# Altium schematic sheet main.SchDoc(main)
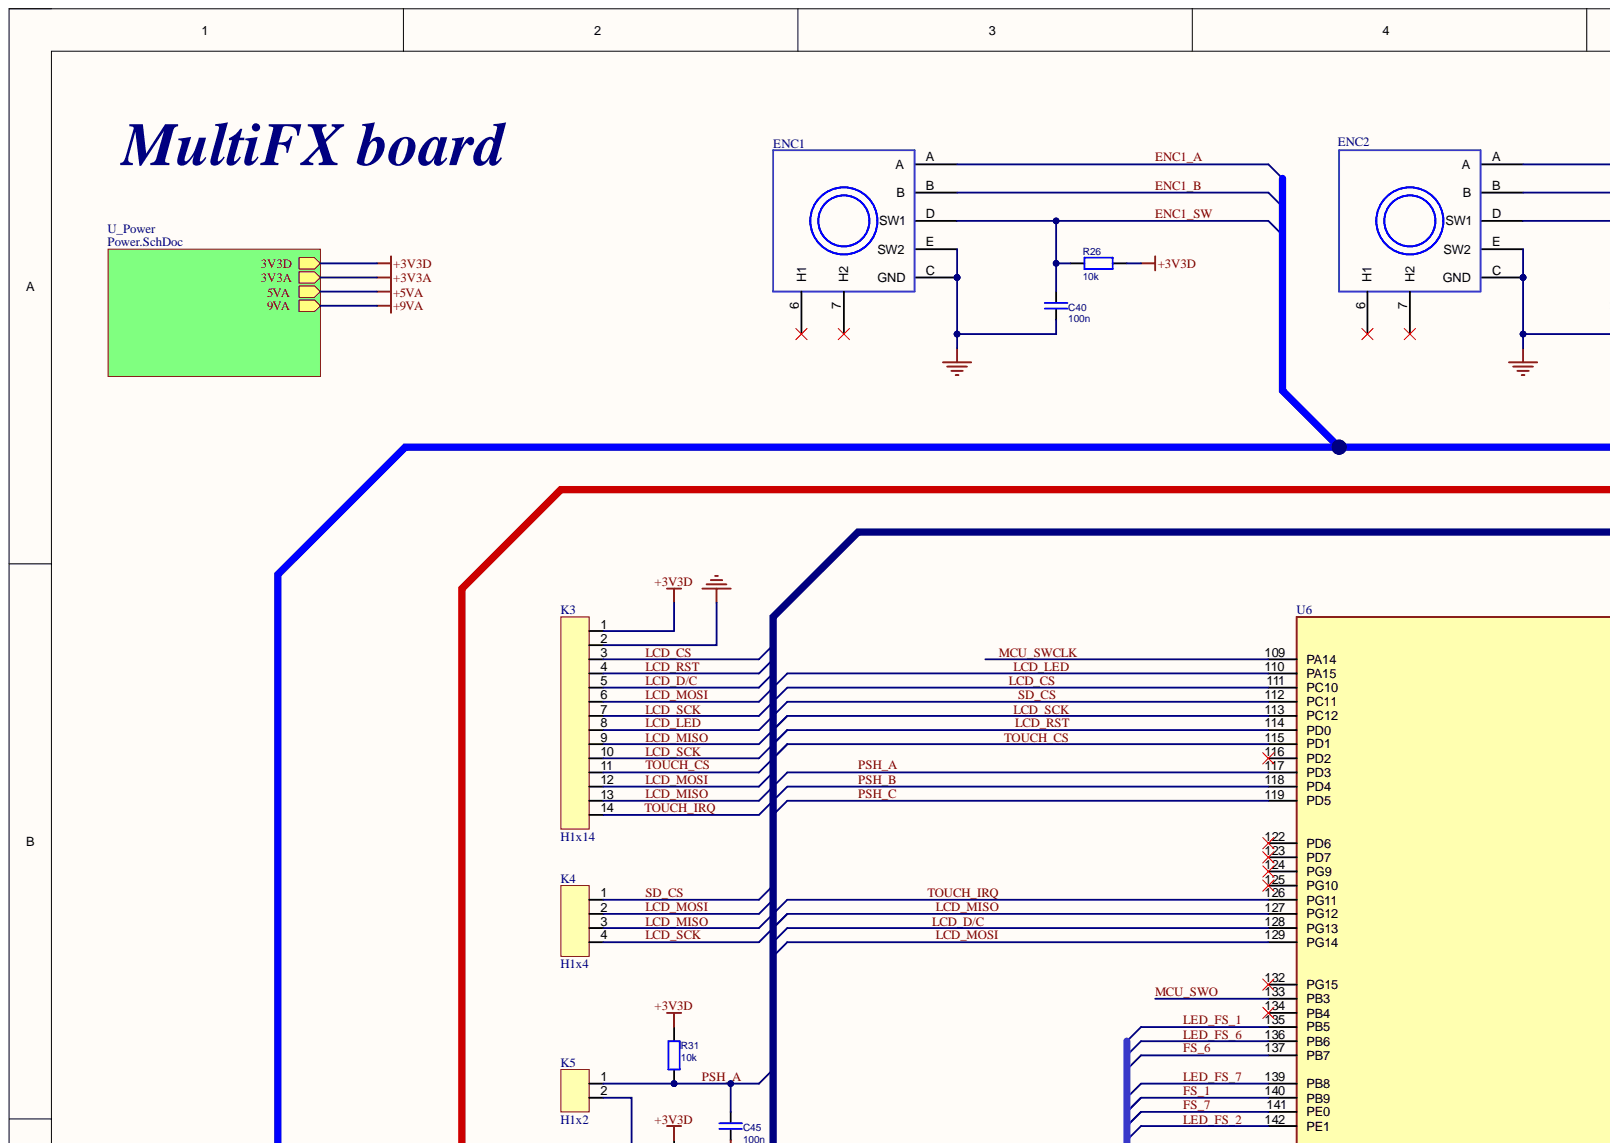
[diagram p0: main.SchDoc, top left]
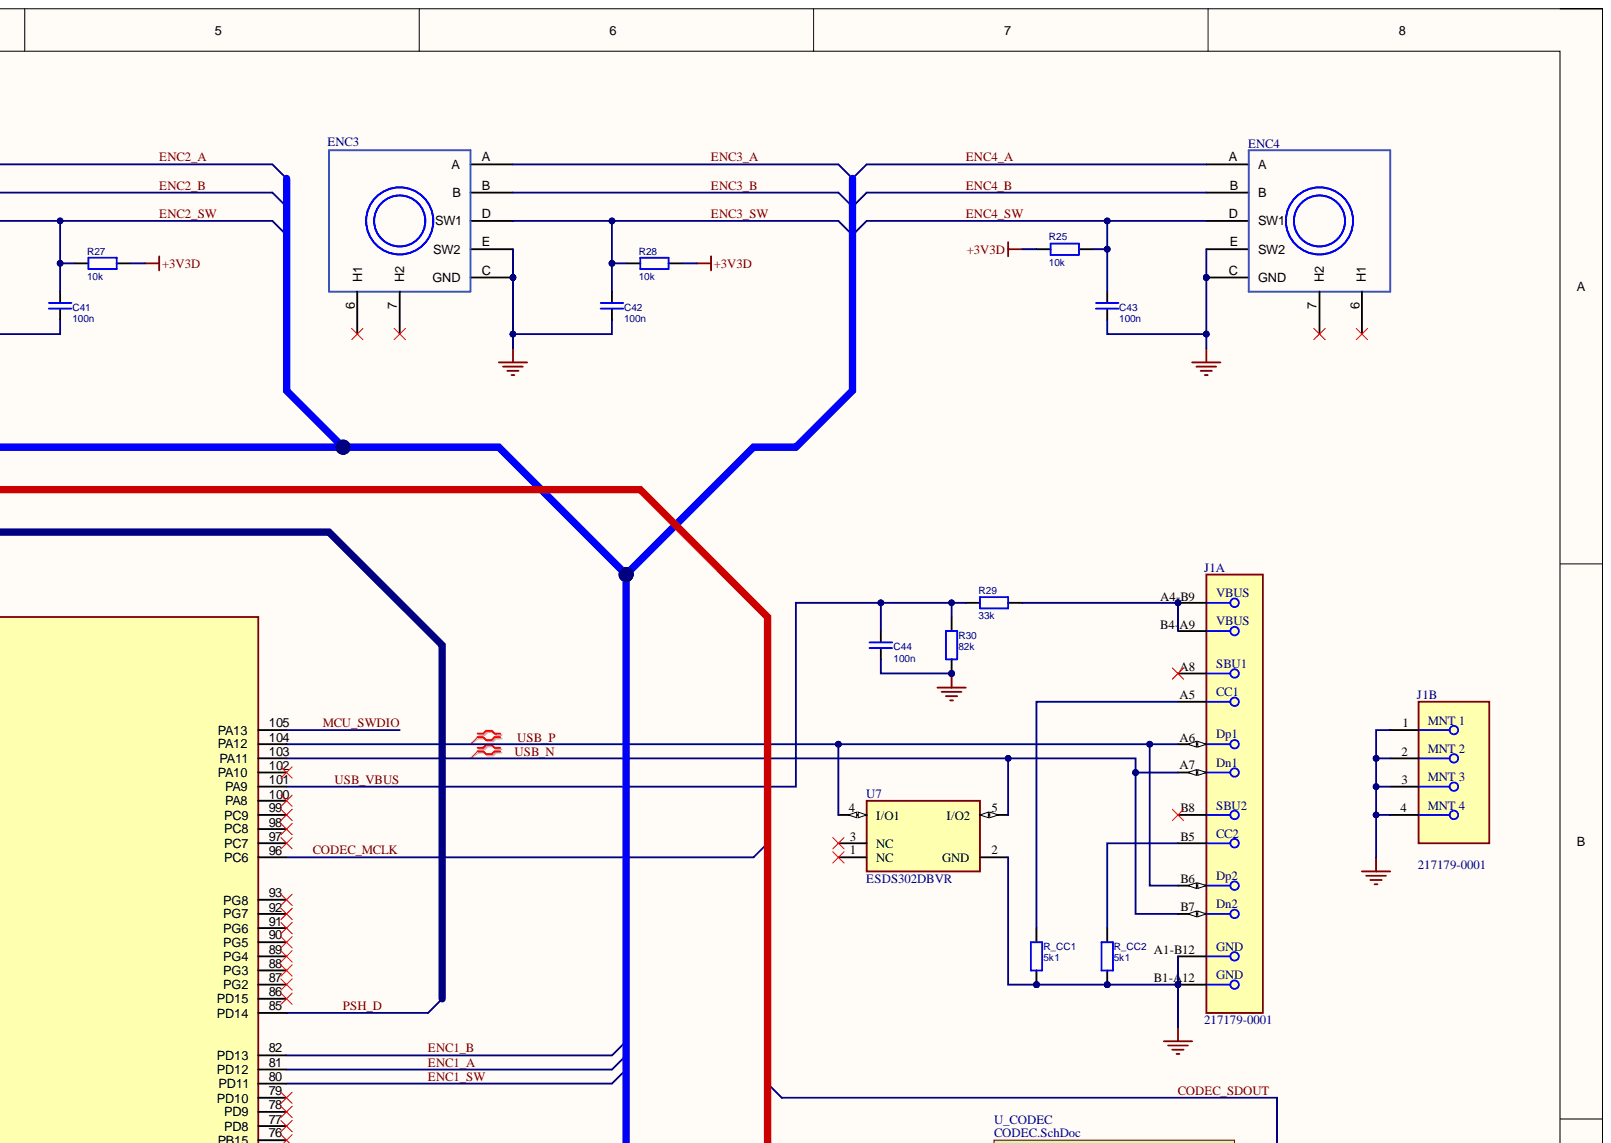
[diagram p1: main.SchDoc, top right]
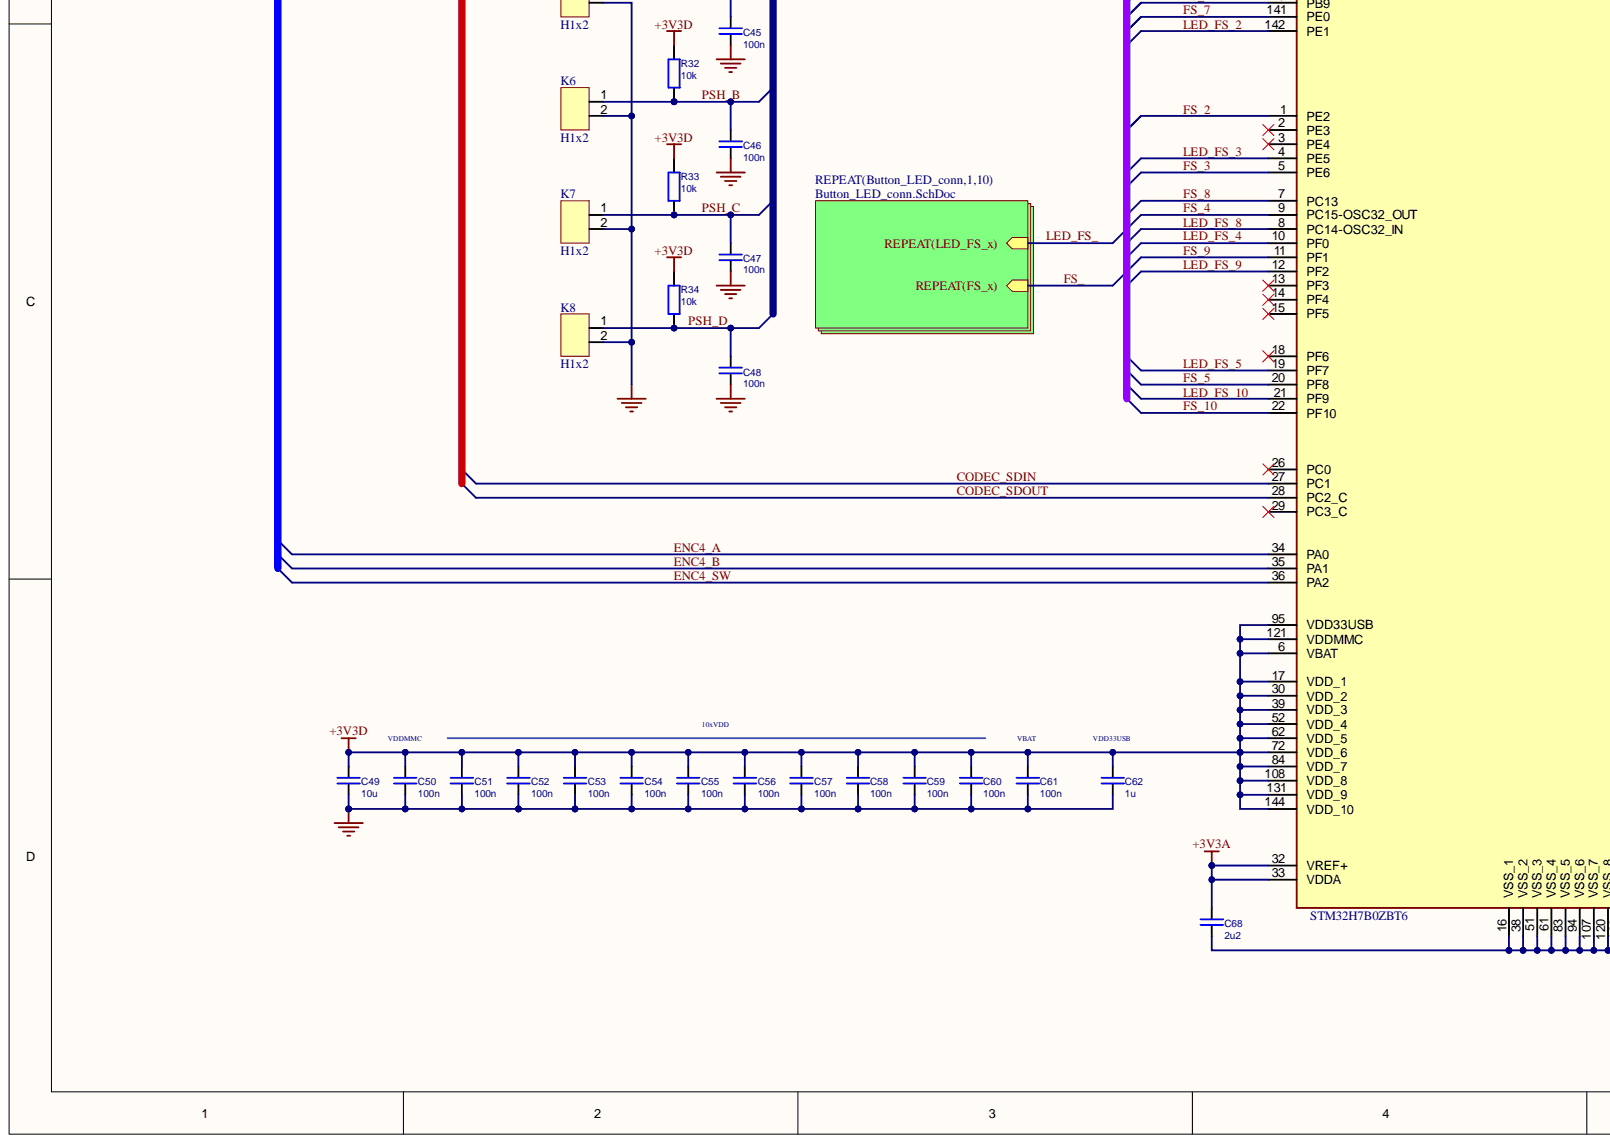
[diagram p2: main.SchDoc, bottom left]
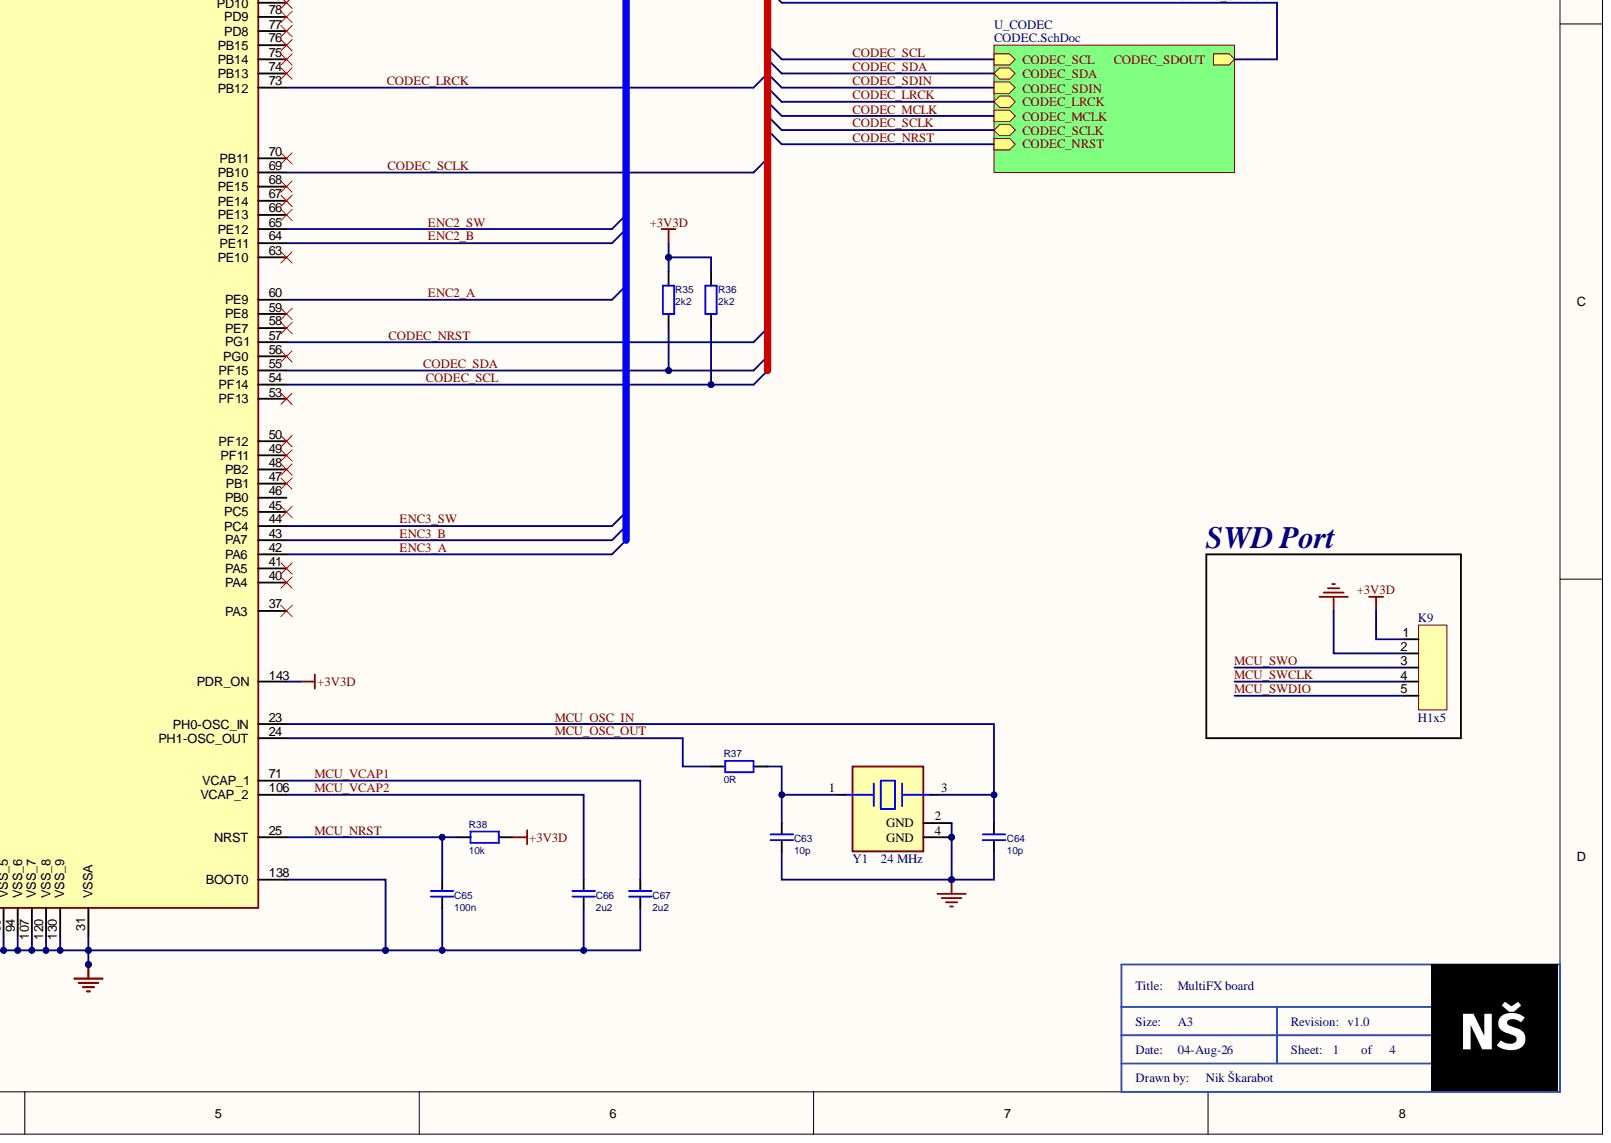
[diagram p3: main.SchDoc, bottom right]

## Parts
C40  100n  [C0603]
C41  100n  [C0603]
C42  100n  [C0603]
C43  100n  [C0603]
C44  100n  [C0603]
C45  100n  [C0603]
C46  100n  [C0603]
C47  100n  [C0603]
C48  100n  [C0603]
C49  10u  [C0603]
C50  100n  [C0603]
C51  100n  [C0603]
C52  100n  [C0603]
C53  100n  [C0603]
C54  100n  [C0603]
C55  100n  [C0603]
C56  100n  [C0603]
C57  100n  [C0603]
C58  100n  [C0603]
C59  100n  [C0603]
C60  100n  [C0603]
C61  100n  [C0603]
C62  1u  [C0603]
C63  10p  [C0603]
C64  10p  [C0603]
C65  100n  [C0603]
C66  2u2  [C0603]
C67  2u2  [C0603]
C68  2u2  [C0603]
ENC1  EC11E09244BS  [Encoder_EC11E09244BS]  (value not drawn)  (no BOM)
ENC2  EC11E09244BS  [Encoder_EC11E09244BS]  (value not drawn)  (no BOM)
ENC3  EC11E09244BS  [Encoder_EC11E09244BS]  (value not drawn)  (no BOM)
ENC4  EC11E09244BS  [Encoder_EC11E09244BS]  (value not drawn)  (no BOM)
J1  217179-0001  [FP-2171790001-MFG]  (parts A,B)
K3  H1x14  [H1x14]  (no BOM)
K4  H1x4  [H1x4]  (no BOM)
K5  H1x2  [H1x2]  (no BOM)
K6  H1x2  [H1x2]  (no BOM)
K7  H1x2  [H1x2]  (no BOM)
K8  H1x2  [H1x2]  (no BOM)
K9  H1x5  [H1x5]  (no BOM)
R25  10k  [R0603]
R26  10k  [R0603]
R27  10k  [R0603]
R28  10k  [R0603]
R29  33k  [R0603]
R30  82k  [R0603]
R31  10k  [R0603]
R32  10k  [R0603]
R33  10k  [R0603]
R34  10k  [R0603]
R35  2k2  [R0603]
R36  2k2  [R0603]
R37  0R  [R0603]
R38  10k  [R0603]
R_CC1  5k1  [R0603]
R_CC2  5k1  [R0603]
U6  STM32H7B0ZBT6  [QFP50P2200X2200X160-144N]
U7  ESDS302DBVR  [FP-DBV0005A-IPC_A]
Y1  24 MHz  [FP-TSX-3225-MFG]

## Nets
+3V3A: C68.1 U6.32(VREF+) U6.33(VDDA)
+3V3D: C49.1 C50.1 C51.1 C52.1 C53.1 C54.1 C55.1 C56.1 C57.1 C58.1 C59.1 C60.1 C61.1 C62.1 K3.1 K9.1 R25.1 R26.1 R27.1 R28.1 R31.1 R32.1 R33.1 R34.1 R35.1 R36.1 R38.2 U6.6(VBAT) U6.17(VDD_1) U6.30(VDD_2) U6.39(VDD_3) U6.52(VDD_4) U6.62(VDD_5) U6.72(VDD_6) U6.84(VDD_7) U6.95(VDD33USB) U6.108(VDD_8) U6.121(VDDMMC) U6.131(VDD_9) U6.143(PDR_ON) U6.144(VDD_10)
GND: C40.2 C41.2 C42.2 C43.2 C44.2 C45.2 C46.2 C47.2 C48.2 C49.2 C50.2 C51.2 C52.2 C53.2 C54.2 C55.2 C56.2 C57.2 C58.2 C59.2 C60.2 C61.2 C62.2 C63.2 C64.2 C65.2 C66.2 C67.2 C68.2 ENC1.C(GND) ENC1.E(SW2) ENC2.C(GND) ENC2.E(SW2) ENC3.C(GND) ENC3.E(SW2) ENC4.C(GND) ENC4.E(SW2) J1.1 J1.2 J1.3 J1.4 J1.A1-B12 J1.B1-A12 K3.2 K5.2 K6.2 K7.2 K8.2 K9.2 R30.1 R_CC1.1 R_CC2.2 U6.16(VSS_1) U6.31(VSSA) U6.38(VSS_2) U6.51(VSS_3) U6.61(VSS_4) U6.83(VSS_5) U6.94(VSS_6) U6.107(VSS_7) U6.120(VSS_8) U6.130(VSS_9) U6.138(BOOT0) U7.2(GND) Y1.2(GND) Y1.4(GND)
CODEC_LRCK: U6.73(PB12)
CODEC_MCLK: U6.96(PC6)
CODEC_NRST: U6.57(PG1)
CODEC_SCL: R36.2 U6.54(PF14)
CODEC_SCLK: U6.69(PB10)
CODEC_SDA: R35.2 U6.55(PF15)
CODEC_SDIN: U6.27(PC1)
CODEC_SDOUT: U6.28(PC2_C)
ENC1_A: ENC1.A U6.81(PD12)
ENC1_B: ENC1.B U6.82(PD13)
ENC1_SW: C40.1 ENC1.D(SW1) R26.2 U6.80(PD11)
ENC2_A: ENC2.A U6.60(PE9)
ENC2_B: ENC2.B U6.64(PE11)
ENC2_SW: C41.1 ENC2.D(SW1) R27.2 U6.65(PE12)
ENC3_A: ENC3.A U6.42(PA6)
ENC3_B: ENC3.B U6.43(PA7)
ENC3_SW: C42.1 ENC3.D(SW1) R28.2 U6.44(PC4)
ENC4_A: ENC4.A U6.34(PA0)
ENC4_B: ENC4.B U6.35(PA1)
ENC4_SW: C43.1 ENC4.D(SW1) R25.2 U6.36(PA2)
FS_1: U6.140(PB9)
FS_2: U6.1(PE2)
FS_3: U6.5(PE6)
FS_4: U6.9(PC15-OSC32_OUT)
FS_5: U6.20(PF8)
FS_6: U6.137(PB7)
FS_7: U6.141(PE0)
FS_8: U6.7(PC13)
FS_9: U6.11(PF1)
FS_10: U6.22(PF10)
LCD_CS: K3.3 U6.111(PC10)
LCD_D/C: K3.5 U6.128(PG13)
LCD_LED: K3.8 U6.110(PA15)
LCD_MISO: K3.9 K3.13 K4.3 U6.127(PG12)
LCD_MOSI: K3.6 K3.12 K4.2 U6.129(PG14)
LCD_RST: K3.4 U6.114(PD0)
LCD_SCK: K3.7 K3.10 K4.4 U6.113(PC12)
LED_FS_1: U6.135(PB5)
LED_FS_2: U6.142(PE1)
LED_FS_3: U6.4(PE5)
LED_FS_4: U6.10(PF0)
LED_FS_5: U6.19(PF7)
LED_FS_6: U6.136(PB6)
LED_FS_7: U6.139(PB8)
LED_FS_8: U6.8(PC14-OSC32_IN)
LED_FS_9: U6.12(PF2)
LED_FS_10: U6.21(PF9)
MCU_NRST: C65.1 R38.1 U6.25(NRST)
MCU_OSC_IN: C64.1 U6.23(PH0-OSC_IN) Y1.3
MCU_OSC_OUT: R37.1 U6.24(PH1-OSC_OUT)
MCU_SWCLK: K9.4 U6.109(PA14)
MCU_SWDIO: K9.5 U6.105(PA13)
MCU_SWO: K9.3 U6.133(PB3)
MCU_VCAP1: C67.1 U6.71(VCAP_1)
MCU_VCAP2: C66.1 U6.106(VCAP_2)
PSH_A: C45.1 K5.1 R31.2 U6.117(PD3)
PSH_B: C46.1 K6.1 R32.2 U6.118(PD4)
PSH_C: C47.1 K7.1 R33.2 U6.119(PD5)
PSH_D: C48.1 K8.1 R34.2 U6.85(PD14)
SD_CS: K4.1 U6.112(PC11)
TOUCH_CS: K3.11 U6.115(PD1)
TOUCH_IRQ: K3.14 U6.126(PG11)
USB_N: J1.A7 J1.B7 U6.103(PA11) U7.5(I/O2)
USB_P: J1.A6 J1.B6 U6.104(PA12) U7.4(I/O1)
USB_VBUS: C44.1 R29.1 R30.2 U6.101(PA9)
NetC63_1: C63.1 R37.2 Y1.1
NetENC1_6: ENC1.6(H1)
NetENC1_7: ENC1.7(H2)
NetENC2_6: ENC2.6(H1)
NetENC2_7: ENC2.7(H2)
NetENC3_6: ENC3.6(H1)
NetENC3_7: ENC3.7(H2)
NetENC4_6: ENC4.6(H1)
NetENC4_7: ENC4.7(H2)
NetJ1_A4-B9: J1.A4-B9 J1.B4-A9 R29.2
NetJ1_A5: J1.A5 R_CC1.2
NetJ1_A8: J1.A8
NetJ1_B5: J1.B5 R_CC2.1
NetJ1_B8: J1.B8
NetU6_2: U6.2(PE3)
NetU6_3: U6.3(PE4)
NetU6_13: U6.13(PF3)
NetU6_14: U6.14(PF4)
NetU6_15: U6.15(PF5)
NetU6_18: U6.18(PF6)
NetU6_26: U6.26(PC0)
NetU6_29: U6.29(PC3_C)
NetU6_37: U6.37(PA3)
NetU6_40: U6.40(PA4)
NetU6_41: U6.41(PA5)
NetU6_45: U6.45(PC5)
NetU6_46: U6.46(PB0)
NetU6_47: U6.47(PB1)
NetU6_48: U6.48(PB2)
NetU6_49: U6.49(PF11)
NetU6_50: U6.50(PF12)
NetU6_53: U6.53(PF13)
NetU6_56: U6.56(PG0)
NetU6_58: U6.58(PE7)
NetU6_59: U6.59(PE8)
NetU6_63: U6.63(PE10)
NetU6_66: U6.66(PE13)
NetU6_67: U6.67(PE14)
NetU6_68: U6.68(PE15)
NetU6_70: U6.70(PB11)
NetU6_74: U6.74(PB13)
NetU6_75: U6.75(PB14)
NetU6_76: U6.76(PB15)
NetU6_77: U6.77(PD8)
NetU6_78: U6.78(PD9)
NetU6_79: U6.79(PD10)
NetU6_86: U6.86(PD15)
NetU6_87: U6.87(PG2)
NetU6_88: U6.88(PG3)
NetU6_89: U6.89(PG4)
NetU6_90: U6.90(PG5)
NetU6_91: U6.91(PG6)
NetU6_92: U6.92(PG7)
NetU6_93: U6.93(PG8)
NetU6_97: U6.97(PC7)
NetU6_98: U6.98(PC8)
NetU6_99: U6.99(PC9)
NetU6_100: U6.100(PA8)
NetU6_102: U6.102(PA10)
NetU6_116: U6.116(PD2)
NetU6_122: U6.122(PD6)
NetU6_123: U6.123(PD7)
NetU6_124: U6.124(PG9)
NetU6_125: U6.125(PG10)
NetU6_132: U6.132(PG15)
NetU6_134: U6.134(PB4)
NetU7_1: U7.1(NC)
NetU7_3: U7.3(NC)
+5VA:
+9VA:

## Library data of the parts
C40-C68 = C: Capacitor; Component Kind: Standard; Library Name: General.IntLib
  C40-C48 C50-C61 C65: Value: 100n
  C49: Value: 10u
  C62: Value: 1u
  C63 C64: Value: 10p
  C66-C68: Value: 2u2
J1 = CMP-04767-000028-2: CONN RCP USB2.0 TYP C 24P SMD RA; NexarPartId: 117190526; Current Rating: 1.25A; Gender: Receptacle; Number of Contacts: 16; Voltage Rating: 30V; Component Kind: Standard; Contact Material: Gold, Nickel; Height: 3.36mm; Library Name: Altium Content Vault; Max Operating Temperature: 85°C; Min Operating Temperature: -40°C; USB Standard: USB 2.0
K3 = H1x14: Header 1x14 (male); Library Name: Kon_Tipk.IntLib
K4 = H1x4: Header 1x4 (male); Library Name: Kon_Tipk.IntLib
K5-K8 = H1x2: Header 1x2 (male); Library Name: Kon_Tipk.IntLib
K9 = H1x5: Header 1x5 (male); Library Name: Kon_Tipk.IntLib
R25-R38 R_CC1 R_CC2 = R: Component Kind: Standard; Description: R; Library Name: General.IntLib
  R25-R28 R31-R34 R38: Value: 10k
  R29: Value: 33k
  R30: Value: 82k
  R35 R36: Value: 2k2
  R37: Value: 0R
  R_CC1 R_CC2: Value: 5k1
U6 = STM32H7B0ZBT6: Integrated Circuit; Manufacturer_Name: STMicroelectronics; Arrow Part Number: STM32H7B0ZBT6; Arrow Price/Stock: https://www.arrow.com/en/products/stm32h7b0zbt6/stmicroelectronics?region=nac; Component Kind: Standard; Datasheet Link: https://www.st.com/resource/en/datasheet/stm32h7b0ab.pdf; Height: 1.6mm; Library Name: SamacSys.SchLib; Manufacturer_Part_Number: STM32H7B0ZBT6; Mouser Part Number: 511-STM32H7B0ZBT6; Mouser Price/Stock: https://www.mouser.co.uk/ProductDetail/STMicroelectronics/STM32H7B0ZBT6?qs=xZ%2FP%252Ba9zWqZdQczjdhQF%2Fg%3D%3D
U7 = CMP-04985-000010-1: FAMILY OF UNI-DIRECTIONAL SURGE; Component Kind: Standard; Library Name: Altium Content Vault; RoHS Compliant: Yes
Y1 = CMP-36405-000018-1: CRYSTAL 24.0000MHZ 9PF SMD; Drive Level: 200uW; ESR (Equivalent Series Resistance): 40R; Frequency: 24MHz; Frequency Stability: 20ppm; Frequency Tolerance: 10ppm; Case/Package: SMD/SMT; Component Kind: Standard; Depth: 2.5mm; Height: 0.024inch; Lead Free: Lead Free; Length: 3.2mm; Library Name: Altium Content Vault; Load Capacitance: 9pF; Max Operating Temperature: 105°C; Min Operating Temperature: -40°C; Mount: Surface Mount; Number of Pins: 4; Package Quantity: 250; Packaging: Tape and Reel; RoHS Compliant: Yes; Series: TSX-3225; Termination: SMD/SMT; Tolerance: 10ppm; Weight: 0.000564oz; Width: 2.5mm
ENC1-ENC4 = EC11E09244BS: Library Name: MyComponents.IntLib
